# Altium schematic sheet [08] RP2040.SchDoc("[08] RP2040")
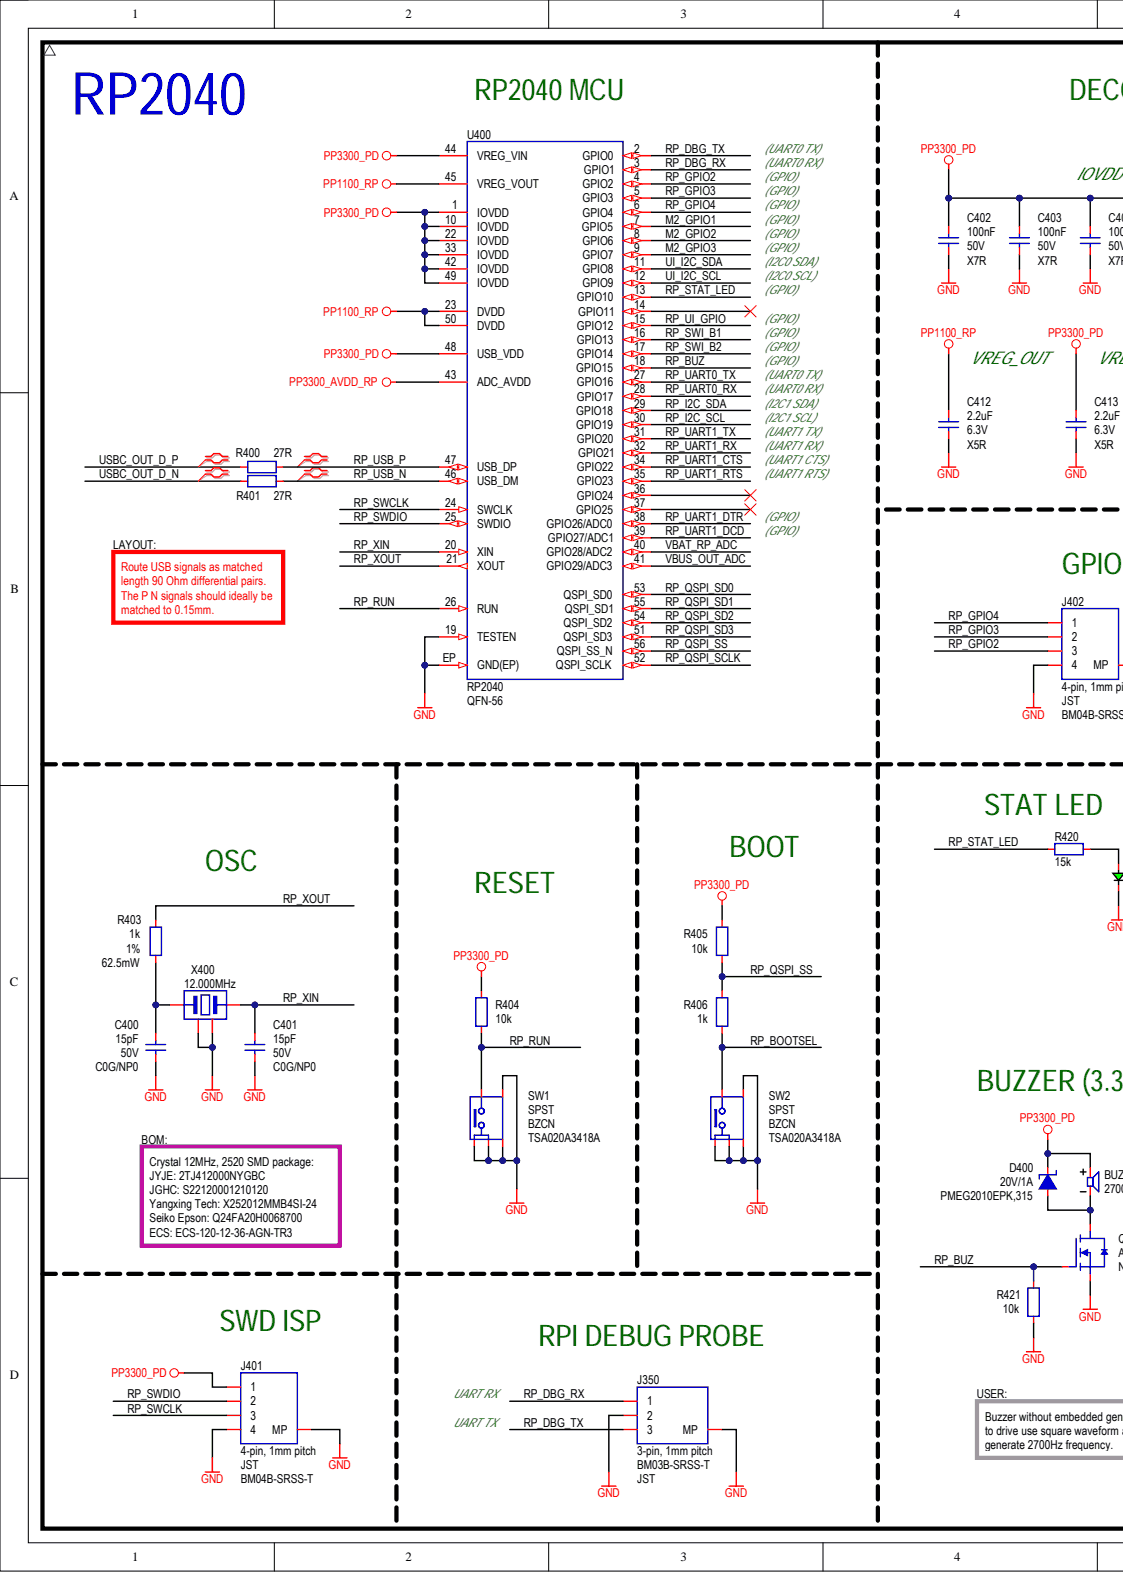
[diagram p0: [08] RP2040.SchDoc, left]
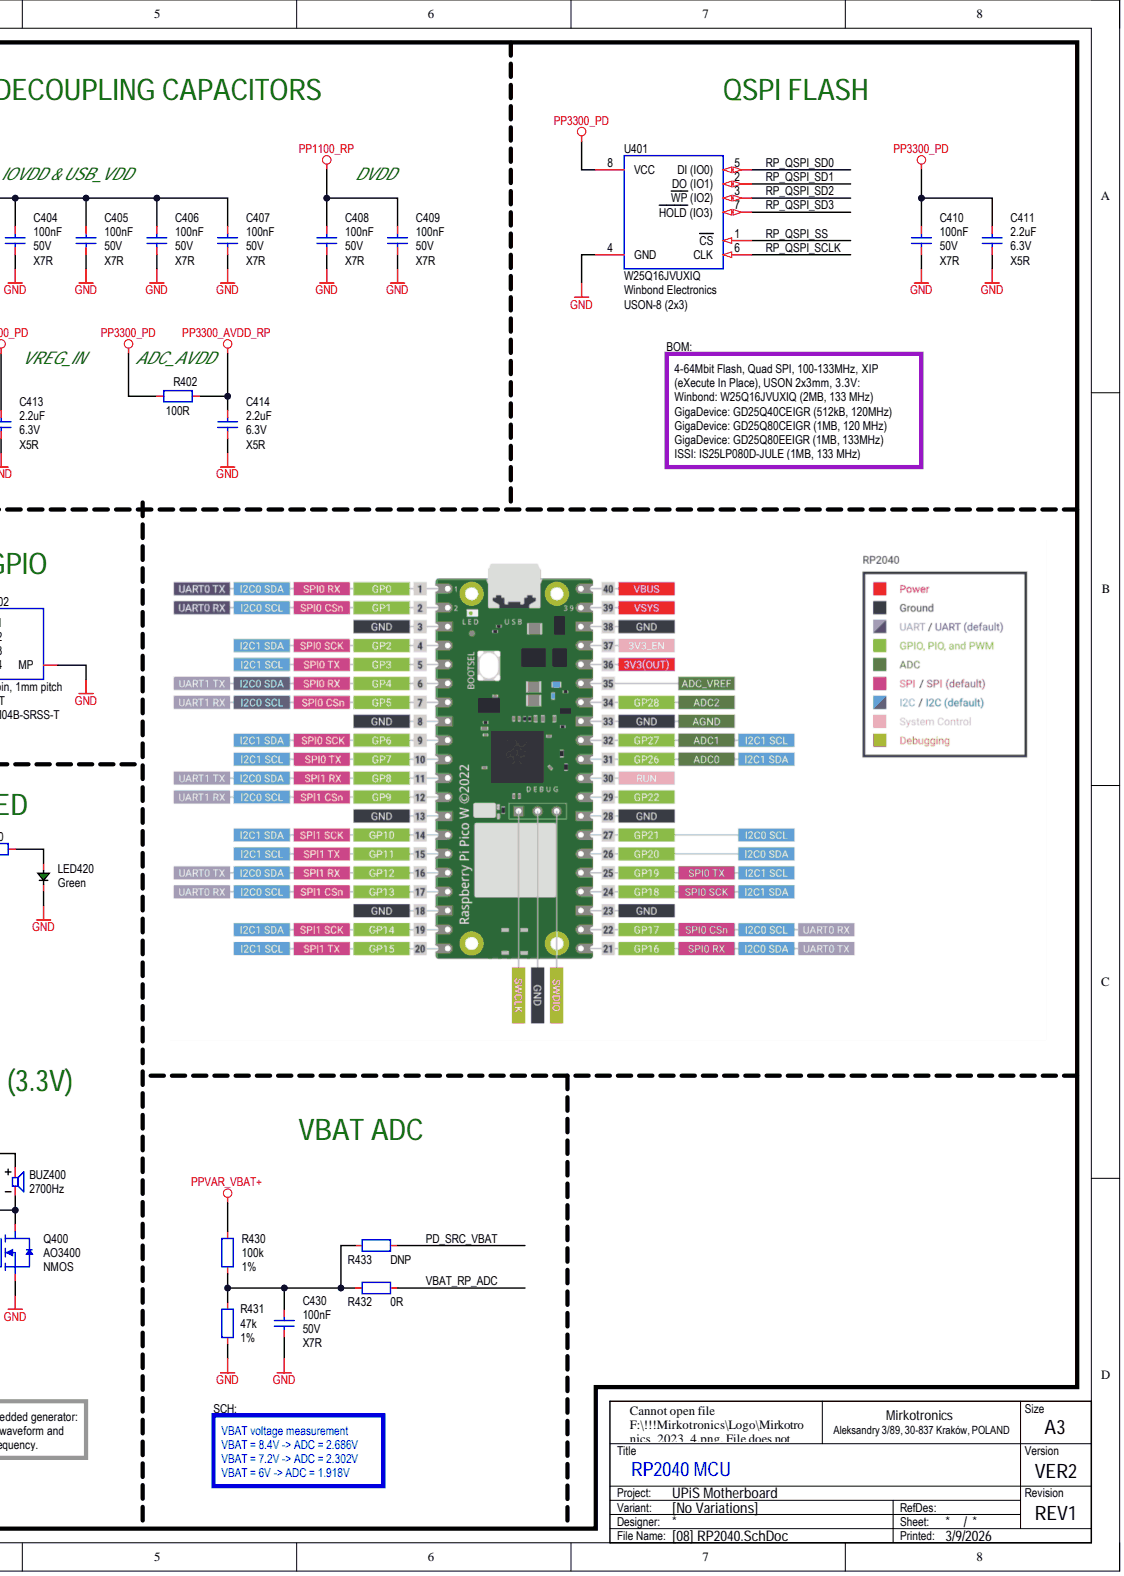
[diagram p1: [08] RP2040.SchDoc, right]

## Parts
BUZ400  2700Hz
C400  15pF  Dielectric: C0G/NP0  Voltage Rating: 50V
C401  15pF  Dielectric: C0G/NP0  Voltage Rating: 50V
C402  100nF  Dielectric: X7R  Voltage Rating: 50V
C403  100nF  Dielectric: X7R  Voltage Rating: 50V
C404  100nF  Dielectric: X7R  Voltage Rating: 50V
C405  100nF  Dielectric: X7R  Voltage Rating: 50V
C406  100nF  Dielectric: X7R  Voltage Rating: 50V
C407  100nF  Dielectric: X7R  Voltage Rating: 50V
C408  100nF  Dielectric: X7R  Voltage Rating: 50V
C409  100nF  Dielectric: X7R  Voltage Rating: 50V
C410  100nF  Dielectric: X7R  Voltage Rating: 50V
C411  2.2uF  Dielectric: X5R  Voltage Rating: 6.3V
C412  2.2uF  Dielectric: X5R  Voltage Rating: 6.3V
C413  2.2uF  Dielectric: X5R  Voltage Rating: 6.3V
C414  2.2uF  Dielectric: X5R  Voltage Rating: 6.3V
C430  100nF  Dielectric: X7R  Voltage Rating: 50V
D400  20V/1A  MPN: PMEG2010EPK,315
J350  3-pin, 1mm pitch  Manufacturer: JST  MPN: BM03B-SRSS-T
J401  4-pin, 1mm pitch  Manufacturer: JST  MPN: BM04B-SRSS-T
J402  4-pin, 1mm pitch  Manufacturer: JST  MPN: BM04B-SRSS-T
LED420  Green
Q400  NMOS  MPN: AO3400
R400  27R
R401  27R
R402  100R
R403  1k  Power Rating: 62.5mW  Tolerance: 1%
R404  10k
R405  10k
R406  1k
R420  15k
R421  10k
R430  100k  Tolerance: 1%
R431  47k  Tolerance: 1%
R432  0R
R433  DNP
SW1  SPST  Manufacturer: BZCN  MPN: TSA020A3418A
SW2  SPST  Manufacturer: BZCN  MPN: TSA020A3418A
U400  RP2040  Package (Case): QFN-56
U401  Manufacturer: Winbond Electronics  MPN: W25Q16JVUXIQ  Package: USON-8 (2x3)  (hidden comment: W25Q64JV)
X400  12.000MHz

## Nets
GND: C400.1 C401.1 C402.1 C403.1 C404.1 C405.1 C406.1 C407.1 C408.1 C409.1 C410.1 C411.1 C412.1 C413.1 C414.1 C430.1 J350.2 J350.MP J401.4 J401.MP J402.4 J402.MP LED420.1 Q400.2 R421.1 R431.2 SW1.1 SW1.3 SW1.SH1 SW1.SH2 SW2.1 SW2.3 SW2.SH1 SW2.SH2 U400.19(TESTEN) U400.EP(GND(EP)) U401.4(GND) X400.2 X400.4
PP1100_RP: C408.2 C409.2 C412.2 U400.23(DVDD) U400.45(VREG_VOUT) U400.50(DVDD)
PP3300_AVDD_RP: C414.2 R402.1 U400.43(ADC_AVDD)
PP3300_PD: BUZ400.2 C402.2 C403.2 C404.2 C405.2 C406.2 C407.2 C410.2 C411.2 C413.2 D400.1 J401.1 R402.2 R404.2 R405.2 U400.1(IOVDD) U400.10(IOVDD) U400.22(IOVDD) U400.33(IOVDD) U400.42(IOVDD) U400.44(VREG_VIN) U400.48(USB_VDD) U400.49(IOVDD) U401.8(VCC)
PPVAR_VBAT+: R430.2
M2_GPIO1: U400.7(GPIO5)
M2_GPIO2: U400.8(GPIO6)
M2_GPIO3: U400.9(GPIO7)
PD_SRC_VBAT: R433.1
RP_BOOTSEL: R406.1 SW2.2
RP_BUZ: Q400.1 R421.2 U400.18(GPIO15)
RP_DBG_RX: J350.1 U400.3(GPIO1)
RP_DBG_TX: J350.3 U400.2(GPIO0)
RP_GPIO2: J402.3 U400.4(GPIO2)
RP_GPIO3: J402.2 U400.5(GPIO3)
RP_GPIO4: J402.1 U400.6(GPIO4)
RP_I2C_SCL: U400.30(GPIO19)
RP_I2C_SDA: U400.29(GPIO18)
RP_QSPI_SCLK: U400.52(QSPI_SCLK) U401.6(CLK)
RP_QSPI_SD0: U400.53(QSPI_SD0) U401.5(DI_(IO0))
RP_QSPI_SD1: U400.55(QSPI_SD1) U401.2(DO_(IO1))
RP_QSPI_SD2: U400.54(QSPI_SD2) U401.3(WP_(IO2))
RP_QSPI_SD3: U400.51(QSPI_SD3) U401.7(HOLD_(IO3))
RP_QSPI_SS: R405.1 R406.2 U400.56(QSPI_SS_N) U401.1(CS)
RP_RUN: R404.1 SW1.2 U400.26(RUN)
RP_STAT_LED: R420.2 U400.13(GPIO10)
RP_SWCLK: J401.3 U400.24(SWCLK)
RP_SWDIO: J401.2 U400.25(SWDIO)
RP_SWI_B1: U400.16(GPIO13)
RP_SWI_B2: U400.17(GPIO14)
RP_UART0_RX: U400.28(GPIO17)
RP_UART0_TX: U400.27(GPIO16)
RP_UART1_CTS: U400.34(GPIO22)
RP_UART1_DCD: U400.39(GPIO27/ADC1)
RP_UART1_DTR: U400.38(GPIO26/ADC0)
RP_UART1_RTS: U400.35(GPIO23)
RP_UART1_RX: U400.32(GPIO21)
RP_UART1_TX: U400.31(GPIO20)
RP_UI_GPIO: U400.15(GPIO12)
RP_USB_N: R401.2 U400.46(USB_DM)
RP_USB_P: R400.2 U400.47(USB_DP)
RP_XIN: C401.2 U400.20(XIN) X400.3
RP_XOUT: R403.1 U400.21(XOUT)
UI_I2C_SCL: U400.12(GPIO9)
UI_I2C_SDA: U400.11(GPIO8)
USBC_OUT_D_N: R401.1
USBC_OUT_D_P: R400.1
VBAT_RP_ADC: R432.2 U400.40(GPIO28/ADC2)
VBUS_OUT_ADC: U400.41(GPIO29/ADC3)
NetBUZ400_1: BUZ400.1 D400.2 Q400.3
NetC400_2: C400.2 R403.2 X400.1
NetC430_2: C430.2 R430.1 R431.1 R432.1 R433.2
NetLED420_2: LED420.2 R420.1

Not connected: U400 14(GPIO11) 36(GPIO24) 37(GPIO25)

## Library data of the parts
BUZ400 = BUZ_POL: Buzzer; Manufacturer: Jiangsu Huaneng Elec; Package (Case): 7.5x7.5x2.5mm; Component Kind: Standard; Component Subtype: Buzzer; Component Type: Buzzer; Mounting Type: SMD; MPN: MLT-7525; Supplier 1: LCSC; Supplier 2: Mouser; Supplier 3: DigiKey; Supplier Part Number 1: C95299; Value: 2700Hz; Library Name: MirFol_lib.SchLib
C400 C401 = CAP-0026: Capacitor; MLCC; GENERIC; TBD; TBD; TBD; TBD; SMD; 0402 (1005);; Manufacturer: KEMET Corporation; MPN: C0402C150J5GACTU; Component Body: 1.00 x 0.50mm; Database Table Name: CAPACITOR$; Capacitance: 15pF; Component Height: TBD; Component Kind: Standard; Component Subtype: MLCC; Component Type: Capacitor; Features: TBD; ID: CAP-0026; Mounting Type: SMD; Operating Temperature: TBD; Package (Case): 0402 (1005); Packaging Type: TBD; ROHS Status: TBD; Supplier 1: LCSC; Supplier 2: Farnell; Supplier 3: Mouser; Supplier Part Number 1: C106997; Supplier Part Number 2: 1414577; Supplier Part Number 3: 80-C0402C150J5G; Tolerance: TBD; Value: 15pF; Library Name: MirFol_db_local.DbLib
C402-C410 C430 = CAP-0026: Capacitor; MLCC; GENERIC; TBD; TBD; TBD; TBD; SMD; 0402 (1005);; Manufacturer: Murata Electronics; MPN: GRM155R71H104KE14D; Component Body: 1.00 x 0.50mm; Database Table Name: CAPACITOR$; Packaging Type: Tape & Reel; Tolerance: 10%; Capacitance: 100nF; Component Height: 0.50mm; Component Kind: Standard; Component Subtype: MLCC; Component Type: Capacitor; Features: Standard; ID: CAP-0004; Mounting Type: SMD; Operating Temperature: -55°C to +125°C; Package (Case): 0402 (1005); ROHS Status: RoHS Compliant; Supplier 1: LCSC; Supplier 2: Mouser; Supplier 3: DigiKey; Supplier Part Number 1: C77020; Value: 100nF; Library Name: MirFol_db_local.DbLib
C411-C414 = CAP-0026: Capacitor; MLCC; GENERIC; TBD; TBD; TBD; TBD; SMD; 0402 (1005);; Manufacturer: Samsung Electro-Mechanics; MPN: CL05A225MQ5NNNC; Component Body: 1.00 x 0.50mm; Database Table Name: CAPACITOR$; Capacitance: 2.2uF; Component Height: TBD; Component Kind: Standard; Component Subtype: MLCC; Component Type: Capacitor; Features: TBD; ID: CAP-0026; Mounting Type: SMD; Operating Temperature: TBD; Package (Case): 0402 (1005); Packaging Type: TBD; ROHS Status: TBD; Supplier 1: LCSC; Supplier 2: Farnell; Supplier 3: Mouser; Supplier Part Number 1: C107371; Supplier Part Number 2: 3013335; Supplier Part Number 3: 187-CL05A225MQ5NNNC; Tolerance: TBD; Value: 2.2uF; Library Name: MirFol_db_local.DbLib
D400 = DIO_SHT_P2_KA: Diode; Schottky;; Manufacturer: Nexperia; Component Subtype: Schottky Diode; Package (Case): SMD 0603 (1608); Component Kind: Standard; Component Type: Diode; Mounting Type: SMD; Supplier 1: LCSC; Supplier Part Number 1: C552829; Value: 20V/1A; Library Name: MirFol_lib.SchLib
J350 = CON_1X03_COL_MP1: Connector; 1 Row;; Component Subtype: Crimp; Package (Case): SMD; Specification: Connector, crimp style, 4-pin, 1mm pitch, vertical, receptacle, SMD; Component Kind: Standard; Component Type: Connector; Mounting Type: SMD; Supplier Part Number 1: C160389; Value: 3-pin, 1mm pitch; Library Name: Pineberry_Lib.SchLib
J401 J402 = CON_1X04_COL_MP: Connector; 1 Row;; Component Subtype: Crimp; Package (Case): SMD; Specification: Connector, crimp style, 4-pin, 1mm pitch, vertical, receptacle, SMD; Component Kind: Standard; Component Type: Connector; Mounting Type: SMD; Supplier Part Number 1: C160390; Value: 4-pin, 1mm pitch; Library Name: MirFol_lib.SchLib
LED420 = DIO_LED_GRN: Diode; LED; Green;; Manufacturer: Foshan NationStar Optoelectronics; MPN: NCD0805G1; Component Subtype: LED Diode; Package (Case): 0805 (2012); Component Kind: Standard; Component Type: LED; Mounting Type: SMD; Supplier: LCSC; Supplier 1: LCSC; Supplier Part Number 1: C84260; Value: Green; Library Name: MirFol_lib.SchLib
Q400 = TRA_NMOS_GSD: Transistor; NMOS;; Manufacturer: Guangdong Hottech; Component Subtype: N-MOSFET; Package (Case): SOT23-3; Component Kind: Standard; Component Type: Transistor; Mounting Type: SMD; Supplier 1: LCSC; Supplier 2: Mouser; Supplier 3: DigiKey; Supplier Part Number 1: C181090; Value: NMOS; Library Name: MirFol_lib.SchLib
R400 R401 = RES-0058: Resistor; Thick Film; GENERIC; TBD; TBD; TBD; TBD; TBD; SMD; 0402 (1005);; Manufacturer: Yageo Corporation; MPN: RC0402FR-0727RL; Component Body: 1.00 x 0.50mm; Database Table Name: RESISTOR$; Power Rating: 62.5mW; Tolerance: 1%; Voltage Rating: 50V; Component Height: TBD; Component Kind: Standard; Component Subtype: Thick Film; Component Type: Resistor; Features: TBD; ID: RES-0058; Mounting Type: SMD; Operating Temperature: TBD; Package (Case): 0402 (1005); Packaging Type: TBD; Resistance: 27R; ROHS Status: TBD; Supplier 1: LCSC; Supplier 2: Farnell; Supplier 3: Mouser; Supplier Part Number 1: C138021; Supplier Part Number 2: 9239049; Supplier Part Number 3: 603-RC0402FR-0727RL; TCR: TBD; Value: 27R; Library Name: MirFol_db_local.DbLib
R402 = RES-0002: Resistor; Thick Film; 100R; 1%; 62.5mW; 100PPM; 50V; Standard; SMD; 0402 (1005);; Manufacturer: Yageo Corporation; MPN: RC0402FR-07100RL; Component Body: 1.00 x 0.50mm; Database Table Name: RESISTOR$; Packaging Type: Tape & Reel; Component Height: 0.35mm; Component Kind: Standard; Component Subtype: Thick Film; Component Type: Resistor; Features: Standard; ID: RES-0002; Mounting Type: SMD; Operating Temperature: -55°C to +155°C; Package (Case): 0402 (1005); Power Rating: 62.5mW; Resistance: 100R; ROHS Status: RoHS Compliant; Supplier 1: LCSC; Supplier 2: Farnell; Supplier 3: Mouser; Supplier Part Number 1: C106232; Supplier Part Number 2: 2421888; Supplier Part Number 3: 603-RC0402FR-07100RL; TCR: 100PPM; Tolerance: 1%; Value: 100R; Voltage Rating: 50V; Library Name: MirFol_db_local.DbLib
R403 R406 = RES-0003: Resistor; Thick Film; 1k; 1%; 62.5mW; 100PPM; 50V; Standard; SMD; 0402 (1005);; Manufacturer: Yageo Corporation; MPN: RC0402FR-071KL; Component Body: 1.00 x 0.50mm; Database Table Name: RESISTOR$; Packaging Type: Tape & Reel; Component Height: 0.35mm; Component Kind: Standard; Component Subtype: Thick Film; Component Type: Resistor; Features: Standard; ID: RES-0003; Mounting Type: SMD; Operating Temperature: -55°C to +155°C; Package (Case): 0402 (1005); Resistance: 1k; ROHS Status: RoHS Compliant; Supplier 1: LCSC; Supplier 2: Farnell; Supplier 3: Mouser; Supplier Part Number 1: C106235; Supplier Part Number 2: 9239235; Supplier Part Number 3: 603-RC0402FR-071KL; TCR: 100PPM; Value: 1k; Voltage Rating: 50V; Library Name: MirFol_db_local.DbLib
  R406: Power Rating: 62.5mW; Tolerance: 1%
R404 R405 R421 = RES-0004: Resistor; Thick Film; 10k; 1%; 62.5mW; 100PPM; 50V; Standard; SMD; 0402 (1005);; Manufacturer: Yageo Corporation; MPN: RC0402FR-0710KL; Component Body: 1.00 x 0.50mm; Database Table Name: RESISTOR$; Packaging Type: Tape & Reel; Component Height: 0.35mm; Component Kind: Standard; Component Subtype: Thick Film; Component Type: Resistor; Features: Standard; ID: RES-0004; Mounting Type: SMD; Operating Temperature: -55°C to +155°C; Package (Case): 0402 (1005); Power Rating: 62.5mW; Resistance: 10k; ROHS Status: RoHS Compliant; Supplier 1: LCSC; Supplier 2: Farnell; Supplier 3: Mouser; Supplier Part Number 1: C60490; Supplier Part Number 2: 9239359; Supplier Part Number 3: 603-RC0402FR-0710KL; TCR: 100PPM; Tolerance: 1%; Value: 10k; Voltage Rating: 50V; Library Name: MirFol_db_local.DbLib
R420 = RES-0058: Resistor; Thick Film; GENERIC; TBD; TBD; TBD; TBD; TBD; SMD; 0402 (1005);; Manufacturer: YAGEO; MPN: RC0402FR-0715KL; Component Body: 1.00 x 0.50mm; Database Table Name: RESISTOR$; Packaging Type: Tape & Reel; Power Rating: 62.5mW; TCR: 100PPM; Tolerance: 1%; Voltage Rating: 50V; Component Height: 0.35mm; Component Kind: Standard; Component Subtype: Thick Film; Component Type: Resistor; Features: Standard; ID: RES-0058; Mounting Type: SMD; Operating Temperature: -55°C to +155°C; Package (Case): 0402 (1005); Resistance: 15k; ROHS Status: RoHS Compliant; Supplier 1: LCSC; Supplier 2: Mouser; Supplier 3: Digikey; Supplier Part Number 1: C114761; Supplier Part Number 2: 603-RC0402FR-0715KL; Supplier Part Number 3: 311-15.0KLRTR-ND; Value: 15k; Library Name: MirFol_db_local.DbLib
R430 = RES-0005: Resistor; Thick Film; 100k; 1%; 62.5mW; 100PPM; 50V; Standard; SMD; 0402 (1005);; Manufacturer: YAGEO; MPN: RC0402FR-07100KL; Component Body: 1.00 x 0.50mm; Database Table Name: RESISTOR$; Packaging Type: Tape & Reel; Component Height: 0.35mm; Component Kind: Standard; Component Subtype: Thick Film; Component Type: Resistor; Features: Standard; ID: RES-0005; Mounting Type: SMD; Operating Temperature: -55°C to +155°C; Package (Case): 0402 (1005); Power Rating: 62.5mW; Resistance: 100k; ROHS Status: RoHS Compliant; Supplier 1: LCSC; Supplier 2: Mouser; Supplier 3: Digikey; Supplier Part Number 1: C60491; Supplier Part Number 2: 603-RC0402FR-07100KL; Supplier Part Number 3: 311-100KLRTR-ND; TCR: 100PPM; Value: 100k; Voltage Rating: 50V; Library Name: MirFol_db_local.DbLib
R431 = RES-0003: Resistor; Thick Film; 1k; 1%; 62.5mW; 100PPM; 50V; Standard; SMD; 0402 (1005);; Manufacturer: Yageo Corporation; MPN: RC0402FR-0747KL; Component Body: 1.00 x 0.50mm; Database Table Name: RESISTOR$; Packaging Type: Tape & Reel; Component Height: 0.35mm; Component Kind: Standard; Component Subtype: Thick Film; Component Type: Resistor; Features: Standard; ID: RES-0003; Mounting Type: SMD; Operating Temperature: -55°C to +155°C; Package (Case): 0402 (1005); Power Rating: 62.5mW; Resistance: 47k; ROHS Status: RoHS Compliant; Supplier 1: LCSC; Supplier 2: Mouser; Supplier 3: Digikey; Supplier Part Number 1: C93943; TCR: 100PPM; Value: 47k; Voltage Rating: 50V; Library Name: MirFol_db_local.DbLib
R432 = RES-0057: Resistor; Thick Film; 0R; 1%; 62.5mW; 100PPM; 50V; Standard; SMD; 0402 (1005);; Manufacturer: YAGEO; MPN: RC0402FR-070RL; Component Body: 1.00 x 0.50mm; Database Table Name: RESISTOR$; Packaging Type: Tape & Reel; Component Height: TBD; Component Kind: Standard; Component Subtype: Thick Film; Component Type: Resistor; Features: Standard; ID: RES-0057; Mounting Type: SMD; Operating Temperature: -55°C to +155°C; Package (Case): 0402 (1005); Power Rating: 62.5mW; Resistance: 0R; ROHS Status: RoHS Compliant; Supplier 1: LCSC; Supplier 2: Mouser; Supplier 3: Digikey; Supplier Part Number 1: C106231; Supplier Part Number 2: 603-RC0402FR-070RL; Supplier Part Number 3: 311-0.0LRTR-ND; TCR: 100PPM; Tolerance: 1%; Value: 0R; Voltage Rating: 50V; Library Name: MirFol_db_local.DbLib
R433 = RES-0058: Resistor; Thick Film; GENERIC; TBD; TBD; TBD; TBD; TBD; SMD; 0402 (1005);; Component Body: 1.00 x 0.50mm; Database Table Name: RESISTOR$; Component Height: TBD; Component Kind: Standard; Component Subtype: Thick Film; Component Type: Resistor; Features: TBD; ID: RES-0058; Manufacturer: GENERIC; Mounting Type: SMD; MPN: DNP; Operating Temperature: TBD; Package (Case): 0402 (1005); Packaging Type: TBD; Power Rating: TBD; Resistance: DNP; ROHS Status: TBD; Supplier 1: LCSC; Supplier 2: Mouser; Supplier 3: Digikey; Supplier Part Number 1: DNP; Supplier Part Number 2: DNP; Supplier Part Number 3: DNP; TCR: TBD; Tolerance: TBD; Value: DNP; Voltage Rating: DNP; Library Name: MirFol_db_local.DbLib
SW1 SW2 = SWI_TACT_3P_ABA_SH: Switch; Tactile; Monostable;; Package (Case): SMD; Specification: Tactile switch, 50mA/12VDC, 160g, SPST, 4.5x3.4mm, SMD package; Component Kind: Standard; Component Type: Switch; Mounting Type: SMD; Operating Temperature: -40°C to +85°C; Supplier 1: LCSC; Supplier 2: Mouser; Supplier 3: DigiKey; Supplier Part Number 1: C2888437; Value: SPST; Library Name: Pineberry_Lib.SchLib
U400 = ICC_RPI_RP2040_QFN56: IC; MCU;; Manufacturer: Raspberry Pi; Component Kind: Standard; Component Subtype: MCU; Component Type: IC; Mounting Type: SMD; MPN: RP2040; Supplier 1: LCSC; Supplier 2: Farnell; Supplier 3: Mouser; Supplier Part Number 1: C2040; Supplier Part Number 2: 3766082; Supplier Part Number 3: 358-RP2040CHIP-7-500; Value: RP2040; Library Name: Pineberry_Lib.SchLib
U401 = ICC_WBD_W25Q64_SOIC_WSON: ICC; Specification: Serial Flash memory, 64Mbit, standard-, dual-, Quad- SPI interface, 133MHz, WSON 5x6mm package; Component Kind: Standard; Component Type: IC; Mounting Type: SMD; Operating Temperature: -40°C to +85°C; Supplier 1: LCSC; Supplier 2: Farnell; Supplier 3: Mouser; Supplier Part Number 1: C2843335; Supplier Part Number 3: 454-W25Q16JVUXIQTR; Value: W25Q64JV; Library Name: Pineberry_Lib.SchLib
X400 = OSC_XTL_P4: Oscillator; Crystal;; Manufacturer: ECS; MPN: ECS-120-12-36-AGN-TR3; Component Kind: Standard; Component Subtype: Crystal; Component Type: Crystal; Mounting Type: SMD; Package (Case): SMD 2520; Supplier 1: LCSC; Supplier 2: Farnell; Supplier 3: Mouser; Supplier Part Number 1: C2149205; Supplier Part Number 2: 2849406; Supplier Part Number 3: 520-120-12-36AGN-TR3; Value: 12.000MHz; Library Name: MirFol_lib.SchLib
